# Altium schematic sheet solenoid.SchDoc
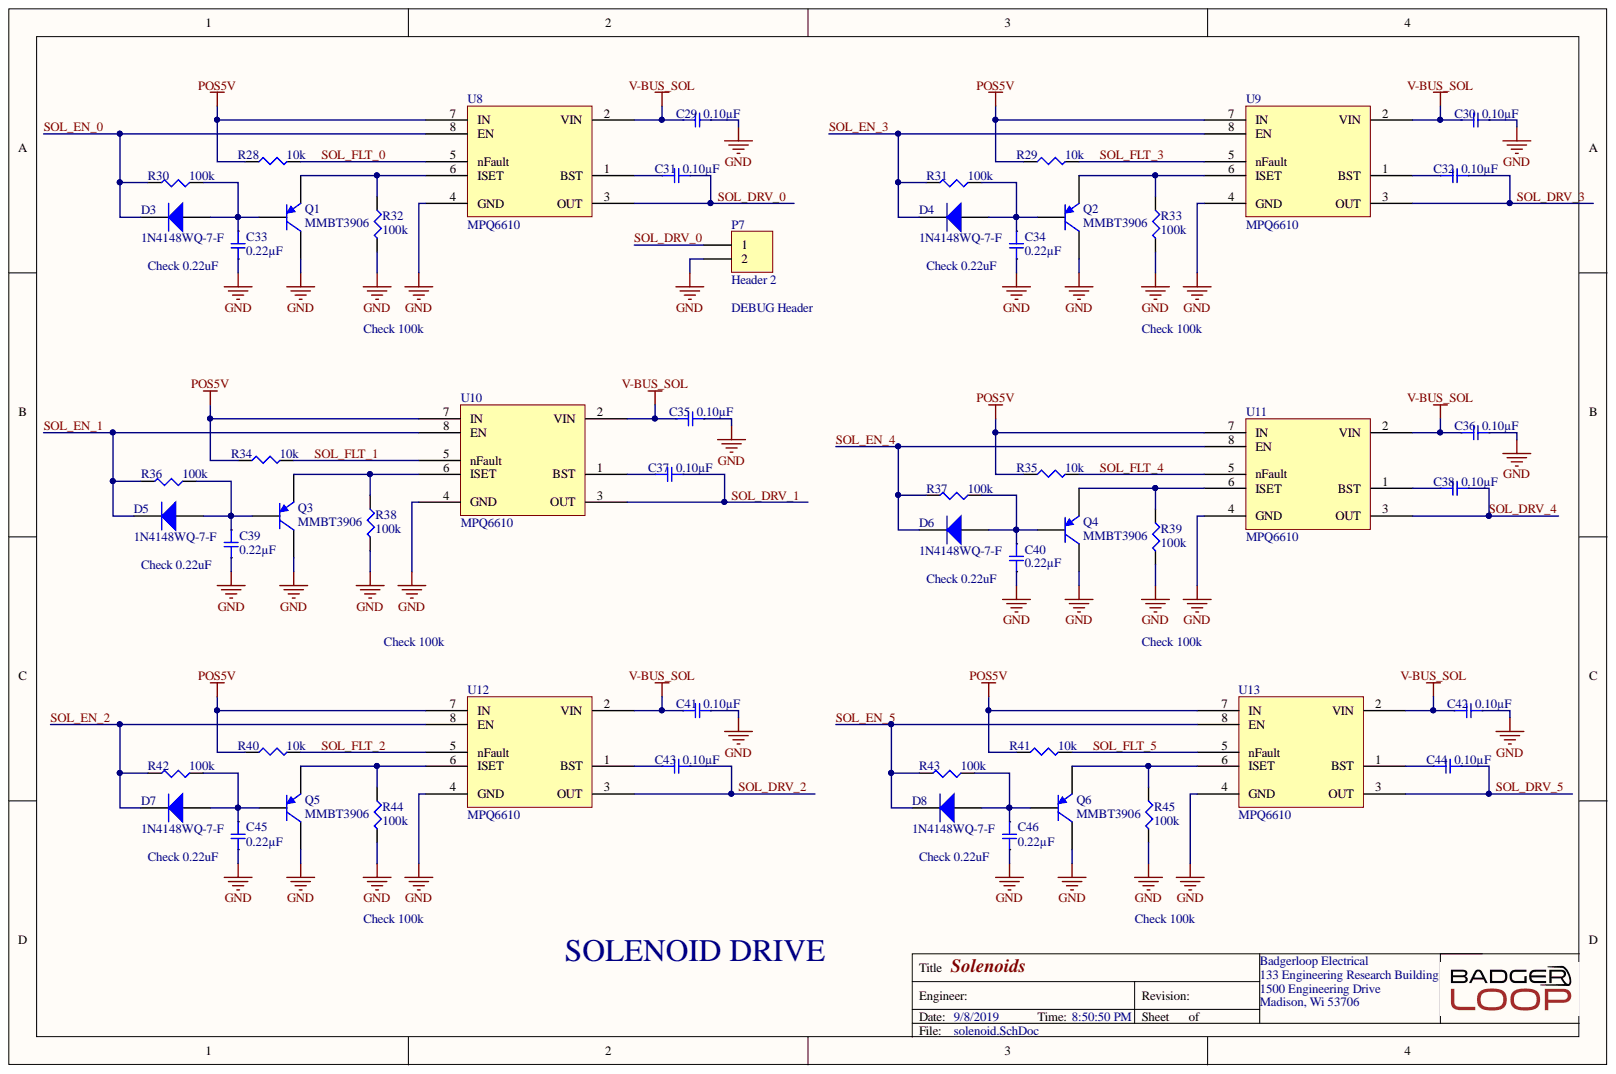
[diagram p0: solenoid.SchDoc]

## Parts
C29  0.10µF  [SMD-0805C]
C30  0.10µF  [SMD-0805C]
C31  0.10µF  [SMD-0805C]
C32  0.10µF  [SMD-0805C]
C33  0.22µF  [SMD-0805C]
C34  0.22µF  [SMD-0805C]
C35  0.10µF  [SMD-0805C]
C36  0.10µF  [SMD-0805C]
C37  0.10µF  [SMD-0805C]
C38  0.10µF  [SMD-0805C]
C39  0.22µF  [SMD-0805C]
C40  0.22µF  [SMD-0805C]
C41  0.10µF  [SMD-0805C]
C42  0.10µF  [SMD-0805C]
C43  0.10µF  [SMD-0805C]
C44  0.10µF  [SMD-0805C]
C45  0.22µF  [SMD-0805C]
C46  0.22µF  [SMD-0805C]
D3  1N4148WQ-7-F  [INDM3838X18N]
D4  1N4148WQ-7-F  [INDM3838X18N]
D5  1N4148WQ-7-F  [INDM3838X18N]
D6  1N4148WQ-7-F  [INDM3838X18N]
D7  1N4148WQ-7-F  [INDM3838X18N]
D8  1N4148WQ-7-F  [INDM3838X18N]
P7  Header 2  [Pin Header 1x2 TH Pitch 2.54mm]
Q1  MMBT3906  [SOTFL103P240X110-3N]
Q2  MMBT3906  [SOTFL103P240X110-3N]
Q3  MMBT3906  [SOTFL103P240X110-3N]
Q4  MMBT3906  [SOTFL103P240X110-3N]
Q5  MMBT3906  [SOTFL103P240X110-3N]
Q6  MMBT3906  [SOTFL103P240X110-3N]
R28  10k  [SMD-0805-RES]
R29  10k  [SMD-0805-RES]
R30  100k  [SMD-0805-RES]
R31  100k  [SMD-0805-RES]
R32  100k  [SMD-0805-RES]
R33  100k  [SMD-0805-RES]
R34  10k  [SMD-0805-RES]
R35  10k  [SMD-0805-RES]
R36  100k  [SMD-0805-RES]
R37  100k  [SMD-0805-RES]
R38  100k  [SMD-0805-RES]
R39  100k  [SMD-0805-RES]
R40  10k  [SMD-0805-RES]
R41  10k  [SMD-0805-RES]
R42  100k  [SMD-0805-RES]
R43  100k  [SMD-0805-RES]
R44  100k  [SMD-0805-RES]
R45  100k  [SMD-0805-RES]
U8  MPQ6610  [SOP127P600X175-8N]
U9  MPQ6610  [SOP127P600X175-8N]
U10  MPQ6610  [SOP127P600X175-8N]
U11  MPQ6610  [SOP127P600X175-8N]
U12  MPQ6610  [SOP127P600X175-8N]
U13  MPQ6610  [SOP127P600X175-8N]

## Nets
GND: C29.2 C30.2 C33.2 C34.2 C35.2 C36.2 C39.2 C40.2 C41.2 C42.2 C45.2 C46.2 P7.2 Q1.3 Q2.3 Q3.3 Q4.3 Q5.3 Q6.3 R32.2 R33.2 R38.2 R39.2 R44.2 R45.2 U8.4(GND) U9.4(GND) U10.4(GND) U11.4(GND) U12.4(GND) U13.4(GND)
POS5V: R28.1 R29.1 R34.1 R35.1 R40.1 R41.1 U8.7(IN) U9.7(IN) U10.7(IN) U11.7(IN) U12.7(IN) U13.7(IN)
V-BUS_SOL: C29.1 C30.1 C35.1 C36.1 C41.1 C42.1 U8.2(VIN) U9.2(VIN) U10.2(VIN) U11.2(VIN) U12.2(VIN) U13.2(VIN)
SOL_DRV_0: C31.2 P7.1 U8.3(OUT)
SOL_DRV_1: C37.2 U10.3(OUT)
SOL_DRV_2: C43.2 U12.3(OUT)
SOL_DRV_3: C32.2 U9.3(OUT)
SOL_DRV_4: C38.2 U11.3(OUT)
SOL_DRV_5: C44.2 U13.3(OUT)
SOL_EN_0: D3.2 R30.2 U8.8(EN)
SOL_EN_1: D5.2 R36.2 U10.8(EN)
SOL_EN_2: D7.2 R42.2 U12.8(EN)
SOL_EN_3: D4.2 R31.2 U9.8(EN)
SOL_EN_4: D6.2 R37.2 U11.8(EN)
SOL_EN_5: D8.2 R43.2 U13.8(EN)
SOL_FLT_0: R28.2 U8.5(nFault)
SOL_FLT_1: R34.2 U10.5(nFault)
SOL_FLT_2: R40.2 U12.5(nFault)
SOL_FLT_3: R29.2 U9.5(nFault)
SOL_FLT_4: R35.2 U11.5(nFault)
SOL_FLT_5: R41.2 U13.5(nFault)
NetC31_1: C31.1 U8.1(BST)
NetC32_1: C32.1 U9.1(BST)
NetC33_1: C33.1 D3.1 Q1.2 R30.1
NetC34_1: C34.1 D4.1 Q2.2 R31.1
NetC37_1: C37.1 U10.1(BST)
NetC38_1: C38.1 U11.1(BST)
NetC39_1: C39.1 D5.1 Q3.2 R36.1
NetC40_1: C40.1 D6.1 Q4.2 R37.1
NetC43_1: C43.1 U12.1(BST)
NetC44_1: C44.1 U13.1(BST)
NetC45_1: C45.1 D7.1 Q5.2 R42.1
NetC46_1: C46.1 D8.1 Q6.2 R43.1
NetQ1_1: Q1.1 R32.1 U8.6(ISET)
NetQ2_1: Q2.1 R33.1 U9.6(ISET)
NetQ3_1: Q3.1 R38.1 U10.6(ISET)
NetQ4_1: Q4.1 R39.1 U11.6(ISET)
NetQ5_1: Q5.1 R44.1 U12.6(ISET)
NetQ6_1: Q6.1 R45.1 U13.6(ISET)

## Library data of the parts
C29-C32 C35-C38 C41-C44 = GRM21B7U1A104JA01L: CAP CER 0.1UF 10V U2J 0805; Manufacturer: Murata Electronics North America; @ qty: 0; Minimum Quantity: 1; Tolerance: ±5%; Unit Price (USD): 0.4; Applications: General Purpose; Component Kind: Standard; Datasheets: http://www.murata.com/~/media/webrenewal/support/library/catalog/products/capacitor/mlcc/c02e.pdf; Digi-Key Part Number: 490-11592-1-ND; Factory Stock: 0; Features: -; Height - Seated (Max): -; Image: //media.digikey.com/Renders/Murata%20Renders/0805%20(2012%20Metric)%20.85mm.jpg; Lead Spacing: -; Lead Style: -; Library Name: capacitors.SchLib; Mounting Type: Surface Mount, MLCC; Operating Temperature: -55°C ~ 125°C; Package / Case: 0805 (2012 Metric); Packaging: Cut Tape (CT); Part Number: GRM21B7U1A104JA01L; Part Status: Active; Quantity Available: 3390; Ratings: -; Series: GRM; Size / Dimension: 0.079" L x 0.049" W (2.00mm x 1.25mm); Temperature Coefficient: U2J; Thickness (Max): 0.053" (1.35mm); Value: 0.10µF; Voltage: 10V
C33 C34 C39 C40 C45 C46 = LLA215R71A224MA14L: CAP CER 0.22UF 10V X7R 0805; Manufacturer: Murata Electronics North America; @ qty: 0; Minimum Quantity: 1; Tolerance: ±20%; Unit Price (USD): 0.36; Applications: Bypass, Decoupling; Component Kind: Standard; Datasheets: http://www.murata.com/~/media/webrenewal/support/library/catalog/products/capacitor/mlcc/c02e.pdf; Digi-Key Part Number: 490-4432-1-ND; Factory Stock: 0; Features: Low ESL (Multi-Terminal); Height - Seated (Max): -; Image: //media.digikey.com/Renders/Murata%20Renders/0805-LLA.jpg; Lead Spacing: -; Lead Style: -; Library Name: capacitors.SchLib; Mounting Type: Surface Mount, MLCC; Operating Temperature: -55°C ~ 125°C; Package / Case: 0805 (2012 Metric); Packaging: Cut Tape (CT); Part Number: LLA215R71A224MA14L; Part Status: Active; Quantity Available: 9914; Ratings: -; Series: LLA; Size / Dimension: 0.079" L x 0.049" W (2.00mm x 1.25mm); Temperature Coefficient: X7R; Thickness (Max): 0.022" (0.55mm); Value: 0.22µF; Voltage: 10V
D3-D8 = 1N4148WQ-7-F: Diodes - General Purpose, Power, Switching SMT Fast Switching Diode; Manufacturer: Diodes Inc.; Component Kind: Standard; Digikey Link: https://www.digikey.com/product-detail/en/diodes-incorporated/1N4148WQ-7-F/1N4148WQ-7-FDITR-ND/8136126; Digikey P/N: 1N4148WQ-7-FDITR-ND; Library Name: misc.SchLib; Manufacturer P/N: 1N4148WQ-7-F
P7 = Header 2: Header, 2-Pin; Component Kind: Standard; LatestRevisionDate: 17-Jul-2002; LatestRevisionNote: Re-released for DXP Platform.; Library Name: connector.SchLib; Signal Integrity: Connector; Publisher: Altium Limited
Q1-Q6 = MMBT3906-7-F: Manufacturer: Diodes Zetex; Collector Base Voltage (VCBO): 40V; Collector Emitter Saturation Voltage: -400mV; Continuous Collector Current: -200mA; Element Configuration: Single; Emitter Base Voltage (VEBO): 6V; Frequency: 250MHz; hFE Min: 100; Max Collector Current: 200mA; Max Power Dissipation: 300mW; Number of Elements: 1; Polarity: PNP; Voltage Rating (DC): -40V; Case/Package: SOT-23; Collector Emitter Breakdown Voltage: 40V; Collector Emitter Voltage (VCEO): 40V; Component Kind: Standard; ComponentLink1Description: https://datasheet.ciiva.com/27052/1540746-27052408.pdf?src-supplier=Jameco; ComponentLink1URL: https://datasheet.ciiva.com/27052/1540746-27052408.pdf?src-supplier=Jameco; ComponentLink2Description: https://datasheet.ciiva.com/15139/getdatasheetpartid-255292-15139821.pdf?src-supplier=Verical; ComponentLink2URL: https://datasheet.ciiva.com/15139/getdatasheetpartid-255292-15139821.pdf?src-supplier=Verical; Contact Plating: Tin,Matte; Current Rating: -200mA; Gain Bandwidth Product: 250MHz; Height: 1mm; Lead Free: Lead Free; Length: 3.05mm; Library Name: misc.SchLib; Manufacturer Part Number: MMBT3906-7-F; Max Breakdown Voltage: 40V; Max Frequency: 250MHz; Max Operating Temperature: 150°C; Min Operating Temperature: -55°C; Mount: Surface Mount; Number of Pins: 3; Package Quantity: 3000; Packaging: Tape and Reel; Power Dissipation: 300mW; Pricing 1: 1=0.12000, 10=0.11400, 100=0.06190, 500=0.03806, 1000=0.02596 (USD); Radiation Hardening: No; REACH SVHC: No SVHC; RoHS: Yes; Stock 1: 687580; Supplier 1: Digi-Key; Supplier Part Number 1: MMBT3906-FDICT-ND; Transition Frequency: 250MHz; Weight: 0.000282oz; Width: 1.4mm
R28 R29 R34 R35 R40 R41 = ERJ-P06J103V: RES SMD 10K OHM 5% 1/2W 0805; Manufacturer: Panasonic Electronic Components; @ qty: 1500; Factory Stock: 0; Minimum Quantity: 1; Power (Watts): 0.5W, 1/2W; Temperature Coefficient: ±200ppm/°C; Unit Price (USD): 0.01839; Component Kind: Standard; Composition: Thick Film; Datasheets: https://industrial.panasonic.com/cdbs/www-data/pdf/RDA0000/AOA0000C243.pdf; Digi-Key Part Number: P10KADCT-ND; Failure Rate: -; Features: Automotive AEC-Q200, Pulse Withstanding; Height - Seated (Max): 0.028" (0.70mm); Image: //media.digikey.com/Renders/Panasonic%20Renders/ERJ-0805%20Pkg.jpg; Library Name: resistors.SchLib; Number of Terminations: 2; Operating Temperature: -55°C ~ 155°C; Package / Case: 0805 (2012 Metric); Packaging: Cut Tape (CT); Part Number: ERJ-P06J103V; Part Status: Active; Quantity Available: 413576; Series: ERJ; Size / Dimension: 0.079" L x 0.049" W (2.00mm x 1.25mm); Supplier Device Package: 0805; Tolerance: ±5%; Value: 10k
R30-R33 R36-R39 R42-R45 = ERJ-P06J104V: RES SMD 100K OHM 5% 1/2W 0805; Manufacturer: Panasonic Electronic Components; @ qty: 1500; Factory Stock: 0; Minimum Quantity: 1; Power (Watts): 0.5W, 1/2W; Temperature Coefficient: ±200ppm/°C; Unit Price (USD): 0.01839; Component Kind: Standard; Composition: Thick Film; Datasheets: https://industrial.panasonic.com/cdbs/www-data/pdf/RDA0000/AOA0000C243.pdf; Digi-Key Part Number: P100KADCT-ND; Failure Rate: -; Features: Automotive AEC-Q200, Pulse Withstanding; Height - Seated (Max): 0.028" (0.70mm); Image: //media.digikey.com/Renders/Panasonic%20Renders/ERJ-0805%20Pkg.jpg; Library Name: resistors.SchLib; Number of Terminations: 2; Operating Temperature: -55°C ~ 155°C; Package / Case: 0805 (2012 Metric); Packaging: Cut Tape (CT); Part Number: ERJ-P06J104V; Part Status: Active; Quantity Available: 82264; Series: ERJ; Size / Dimension: 0.079" L x 0.049" W (2.00mm x 1.25mm); Supplier Device Package: 0805; Tolerance: ±5%; Value: 100k
U8-U13 = MPQ6610: Component Kind: Standard; Library Name: ic.SchLib
